# Altium schematic sheet DryCab.SchDoc
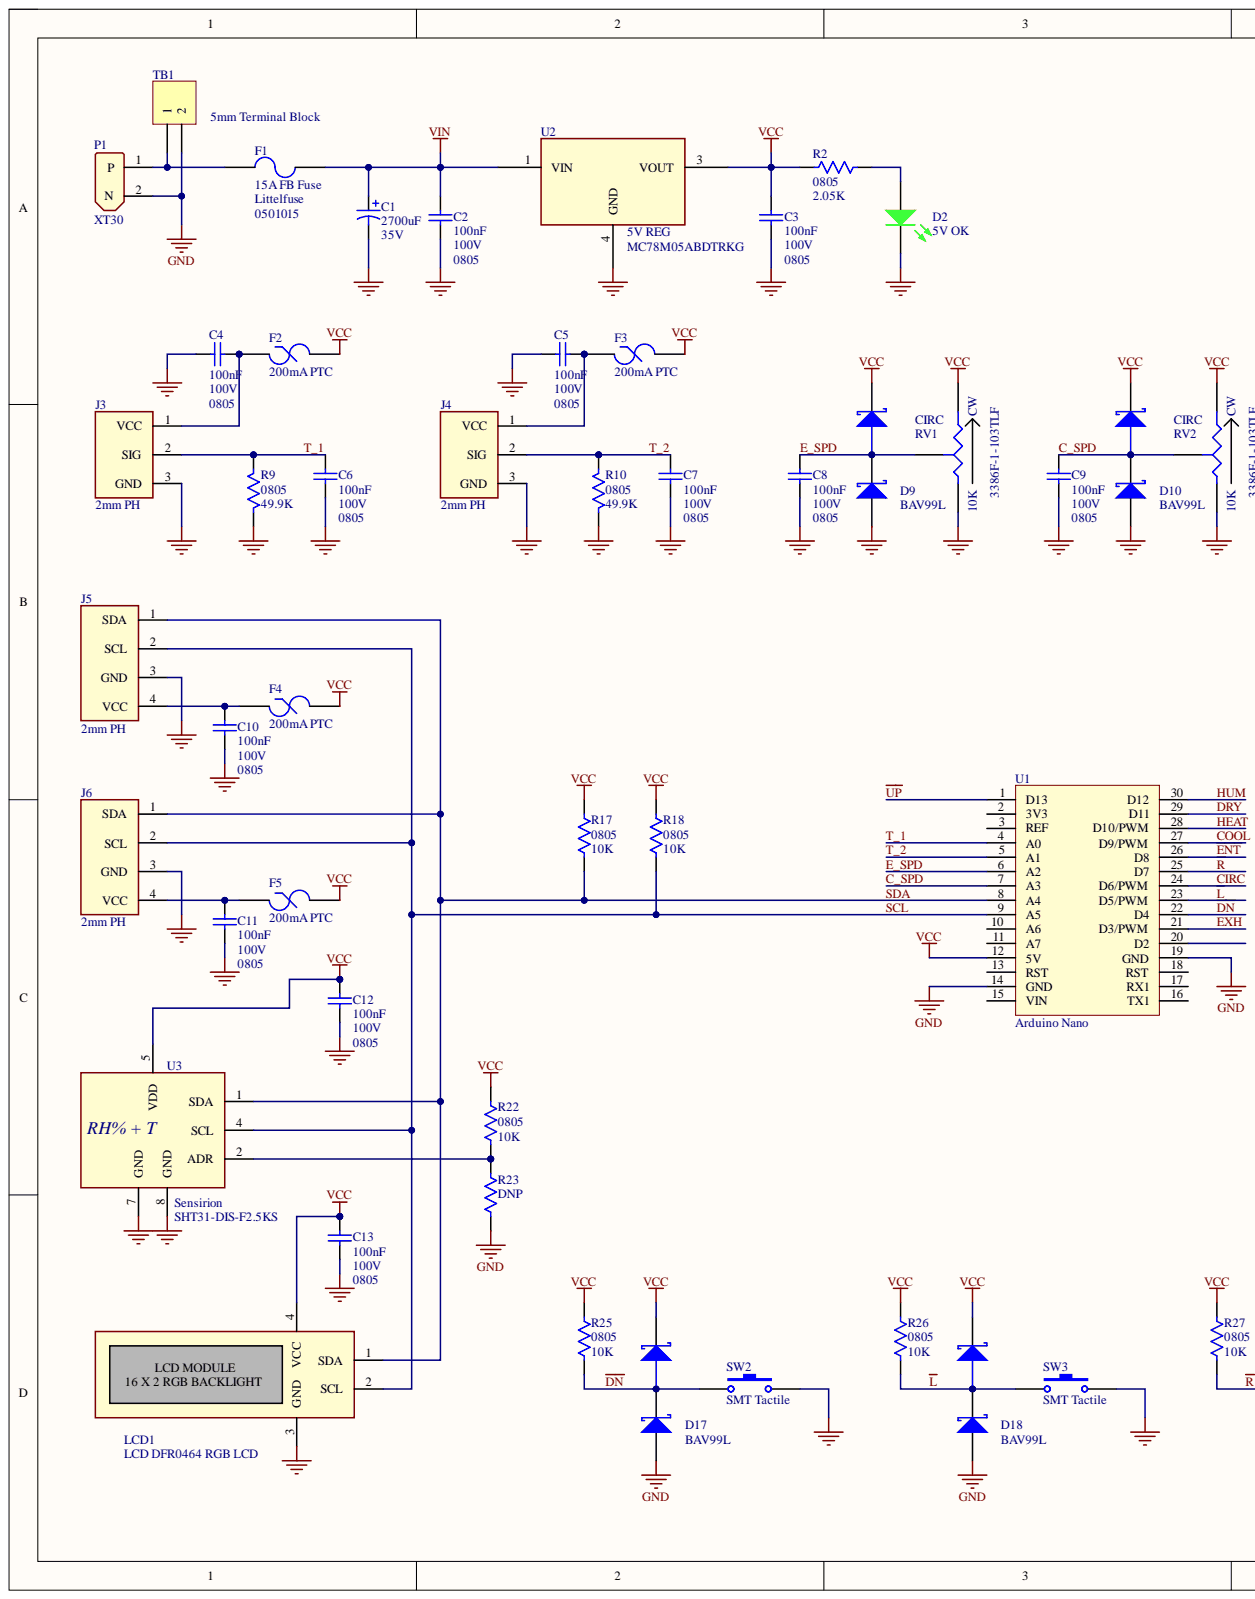
[diagram p0: DryCab.SchDoc, left]
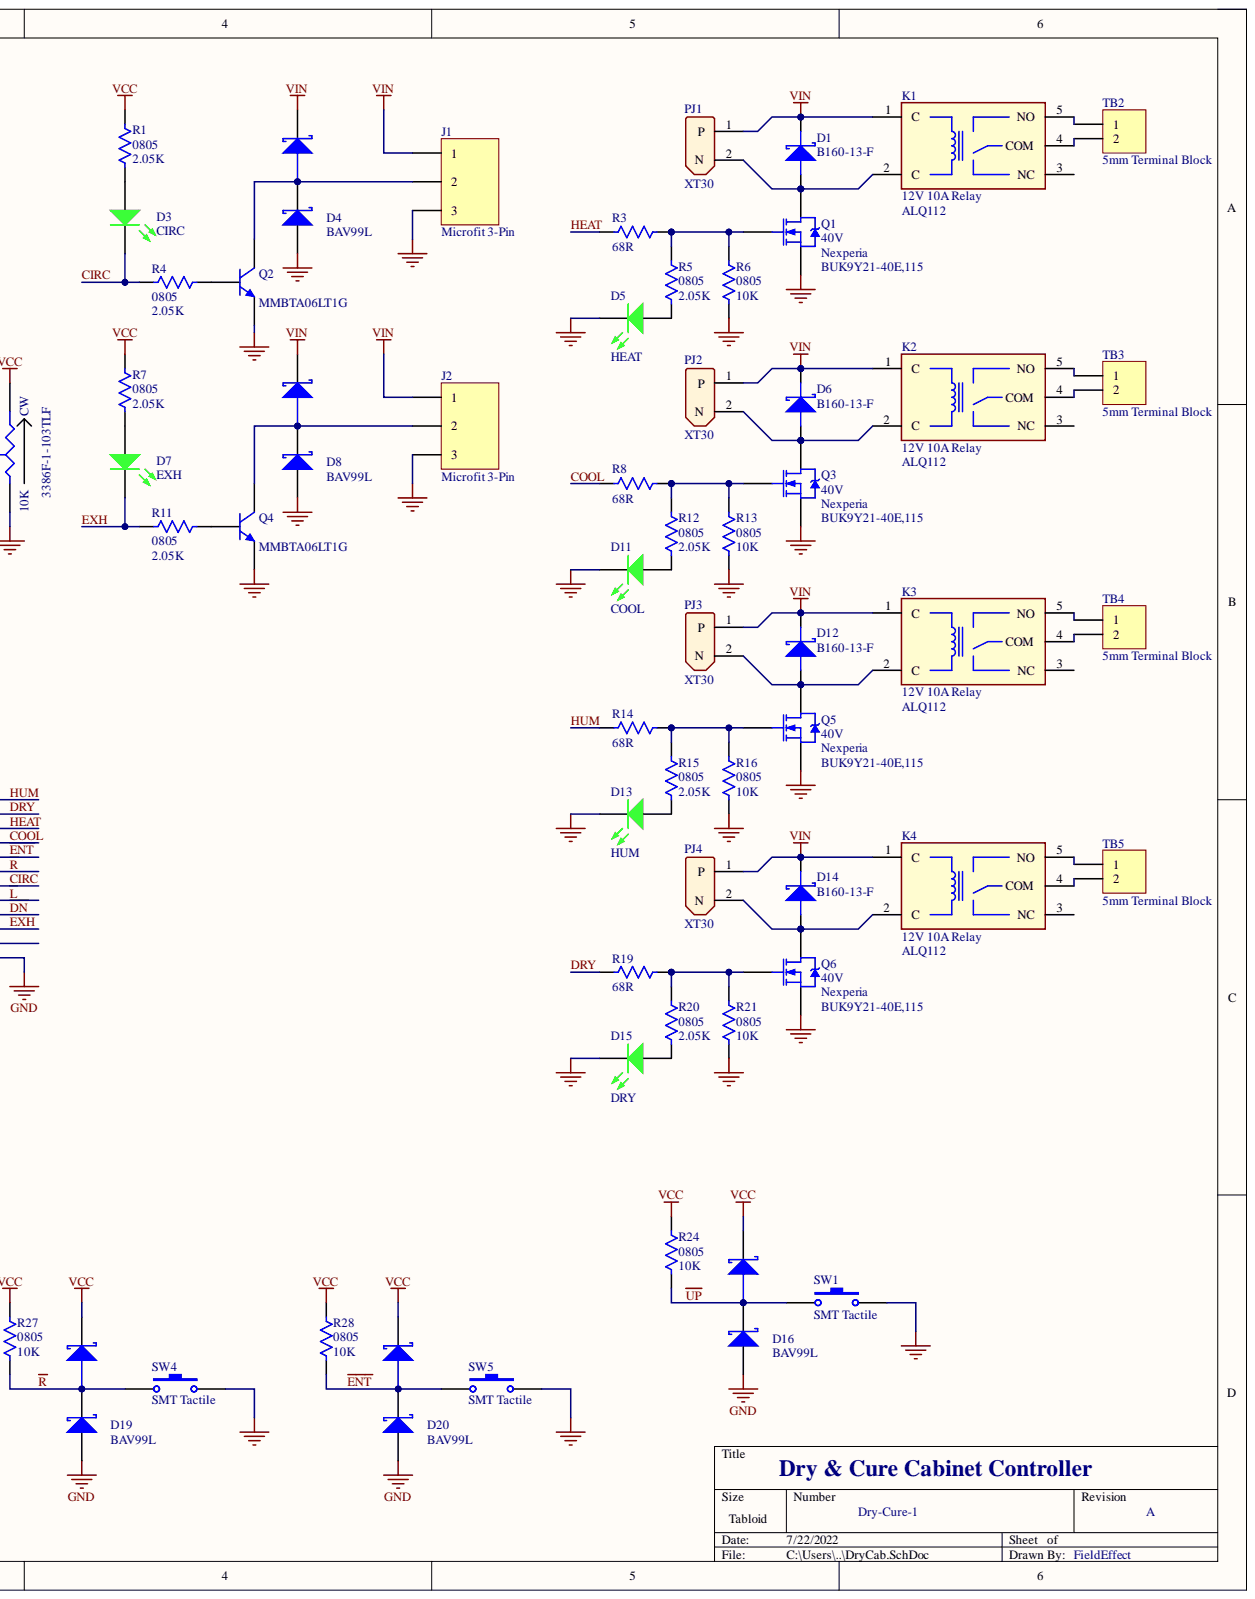
[diagram p1: DryCab.SchDoc, right]

## Parts
C1  value 2700uF  Voltage: 35V  [CAPAE209191X220M]  (hidden comment: C18mm_2700_35V)
C2  value 100nF  Voltage - Rated: 100V  [0805]  (hidden comment: C0805_100nF_100V_X7R_HR)
C3  value 100nF  Voltage - Rated: 100V  [0805]  (hidden comment: C0805_100nF_100V_X7R_HR)
C4  value 100nF  Voltage - Rated: 100V  [0805]  (hidden comment: C0805_100nF_100V_X7R_HR)
C5  value 100nF  Voltage - Rated: 100V  [0805]  (hidden comment: C0805_100nF_100V_X7R_HR)
C6  value 100nF  Voltage - Rated: 100V  [0805]  (hidden comment: C0805_100nF_100V_X7R_HR)
C7  value 100nF  Voltage - Rated: 100V  [0805]  (hidden comment: C0805_100nF_100V_X7R_HR)
C8  value 100nF  Voltage - Rated: 100V  [0805]  (hidden comment: C0805_100nF_100V_X7R_HR)
C9  value 100nF  Voltage - Rated: 100V  [0805]  (hidden comment: C0805_100nF_100V_X7R_HR)
C10  value 100nF  Voltage - Rated: 100V  [0805]  (hidden comment: C0805_100nF_100V_X7R_HR)
C11  value 100nF  Voltage - Rated: 100V  [0805]  (hidden comment: C0805_100nF_100V_X7R_HR)
C12  value 100nF  Voltage - Rated: 100V  [0805]  (hidden comment: C0805_100nF_100V_X7R_HR)
C13  value 100nF  Voltage - Rated: 100V  [0805]  (hidden comment: C0805_100nF_100V_X7R_HR)
D1  B160-13-F  [SMA]
D2  5V OK  [1206 LEDG]
D3  CIRC  [1206 LEDG]
D4  BAV99L  [SOT95P237X100-3N]
D5  HEAT  [1206 LEDG]
D6  B160-13-F  [SMA]
D7  EXH  [1206 LEDG]
D8  BAV99L  [SOT95P237X100-3N]
D9  BAV99L  [SOT95P237X100-3N]
D10  BAV99L  [SOT95P237X100-3N]
D11  COOL  [1206 LEDG]
D12  B160-13-F  [SMA]
D13  HUM  [1206 LEDG]
D14  B160-13-F  [SMA]
D15  DRY  [1206 LEDG]
D16  BAV99L  [SOT95P237X100-3N]
D17  BAV99L  [SOT95P237X100-3N]
D18  BAV99L  [SOT95P237X100-3N]
D19  BAV99L  [SOT95P237X100-3N]
D20  BAV99L  [SOT95P237X100-3N]
F1  15A FB Fuse  Manufacturer: Littelfuse  Manufacturer Part Number: 0501015  [1206 - PTC]
F2  200mA PTC  [1206 - PTC]
F3  200mA PTC  [1206 - PTC]
F4  200mA PTC  [1206 - PTC]
F5  200mA PTC  [1206 - PTC]
J1  Microfit 3-Pin  [MICROFIT 3-PIN FLAT VERT]
J2  Microfit 3-Pin  [MICROFIT 3-PIN FLAT VERT]
J3  2mm PH  [PH-3 THV cab]
J4  2mm PH  [PH-3 THV cab]
J5  2mm PH  [PH-4 THV CAB]
J6  2mm PH  [PH-4 THV CAB]
K1  12V 10A Relay  Coil Voltage (DC): 12V  Contact Current Rating: 10A  Manufacturer Part Number: ALQ112  [ALQ112 RELAY]
K2  12V 10A Relay  Coil Voltage (DC): 12V  Contact Current Rating: 10A  Manufacturer Part Number: ALQ112  [ALQ112 RELAY]
K3  12V 10A Relay  Coil Voltage (DC): 12V  Contact Current Rating: 10A  Manufacturer Part Number: ALQ112  [ALQ112 RELAY]
K4  12V 10A Relay  Coil Voltage (DC): 12V  Contact Current Rating: 10A  Manufacturer Part Number: ALQ112  [ALQ112 RELAY]
LCD1  LCD DFR0464 RGB LCD  [DFR I2C 16X2 DISP MOD]
P1  XT30  [XT30V]
PJ1  XT30  [XT30V]
PJ2  XT30  [XT30V]
PJ3  XT30  [XT30V]
PJ4  XT30  [XT30V]
Q1  40V  Manufacturer: Nexperia  Manufacturer Part Number: BUK9Y21-40E,115  [SOIC127P600X120-8N]
Q2  Manufacturer Part Number: MMBTA06LT1G  [SOT95P237X100-3N]  (hidden comment: NPN MMBTA06L SOT-23)
Q3  40V  Manufacturer: Nexperia  Manufacturer Part Number: BUK9Y21-40E,115  [SOIC127P600X120-8N]
Q4  Manufacturer Part Number: MMBTA06LT1G  [SOT95P237X100-3N]  (hidden comment: NPN MMBTA06L SOT-23)
Q5  40V  Manufacturer: Nexperia  Manufacturer Part Number: BUK9Y21-40E,115  [SOIC127P600X120-8N]
Q6  40V  Manufacturer: Nexperia  Manufacturer Part Number: BUK9Y21-40E,115  [SOIC127P600X120-8N]
R1  value 2.05K  [0805]  (hidden comment: R0805)
R2  value 2.05K  [0805]  (hidden comment: R0805)
R3  value 68R  [0805]
R4  value 2.05K  [0805]  (hidden comment: R0805)
R5  value 2.05K  [0805]  (hidden comment: R0805)
R6  value 10K  [0805]  (hidden comment: R0805)
R7  value 2.05K  [0805]  (hidden comment: R0805)
R8  value 68R  [0805]
R9  value 49.9K  [0805]  (hidden comment: R0805)
R10  value 49.9K  [0805]  (hidden comment: R0805)
R11  value 2.05K  [0805]  (hidden comment: R0805)
R12  value 2.05K  [0805]  (hidden comment: R0805)
R13  value 10K  [0805]  (hidden comment: R0805)
R14  value 68R  [0805]
R15  value 2.05K  [0805]  (hidden comment: R0805)
R16  value 10K  [0805]  (hidden comment: R0805)
R17  value 10K  [0805]  (hidden comment: R0805)
R18  value 10K  [0805]  (hidden comment: R0805)
R19  value 68R  [0805]
R20  value 2.05K  [0805]  (hidden comment: R0805)
R21  value 10K  [0805]  (hidden comment: R0805)
R22  value 10K  [0805]  (hidden comment: R0805)
R23  value DNP  [0805]  (hidden comment: R0805)
R24  value 10K  [0805]  (hidden comment: R0805)
R25  value 10K  [0805]  (hidden comment: R0805)
R26  value 10K  [0805]  (hidden comment: R0805)
R27  value 10K  [0805]  (hidden comment: R0805)
R28  value 10K  [0805]  (hidden comment: R0805)
RV1  CIRC  Manufacturer Part Number: 3386F-1-103TLF  Resistance: 10K  [POT 3386F-T]
RV2  CIRC  Manufacturer Part Number: 3386F-1-103TLF  Resistance: 10K  [POT 3386F-T]
SW1  SMT Tactile  [TL3305 PUSHBUTTON]
SW2  SMT Tactile  [TL3305 PUSHBUTTON]
SW3  SMT Tactile  [TL3305 PUSHBUTTON]
SW4  SMT Tactile  [TL3305 PUSHBUTTON]
SW5  SMT Tactile  [TL3305 PUSHBUTTON]
TB1  5mm Terminal Block  [2-Pin 5mm Term Block]
TB2  5mm Terminal Block  [2-Pin 5mm Term Block]
TB3  5mm Terminal Block  [2-Pin 5mm Term Block]
TB4  5mm Terminal Block  [2-Pin 5mm Term Block]
TB5  5mm Terminal Block  [2-Pin 5mm Term Block]
U1  Arduino Nano  [ARDUINONANO]
U2  5V REG  Manufacturer Part Number: MC78M05ABDTRKG  Min Output Voltage: 5V  [DPAK228P990X238-3N]
U3  Manufacturer: Sensirion  Manufacturer Part Number: SHT31-DIS-F2.5KS  [QFN50P250X250X100_HS-9N]  (hidden comment: Enviro. Sensor)

## Nets
GND: C1.2 C2.2 C3.2 C4.1 C5.1 C6.2 C7.2 C8.2 C9.2 C10.1 C11.1 C12.1 C13.1 D2.2 D4.1 D5.2 D8.1 D9.1 D10.1 D11.2 D13.2 D15.2 D16.1 D17.1 D18.1 D19.1 D20.1 J1.3 J2.3 J3.3 J4.3 J5.3(GND) J6.3(GND) LCD1.3(GND) P1.2 Q1.1 Q2.2 Q3.1 Q4.2 Q5.1 Q6.1 R6.2 R9.2 R10.2 R13.2 R16.2 R21.2 R23.2 RV1.1 RV2.1 SW1.2 SW2.2 SW3.2 SW4.2 SW5.2 TB1.2 U1.14(GND) U1.19(GND) U2.4 U3.7(GND) U3.8(GND)
VCC: C3.1 C12.2 C13.2 D9.2 D10.2 D16.2 D17.2 D18.2 D19.2 D20.2 F2.1 F3.1 F4.1 F5.1 LCD1.4(VCC) R1.2 R2.1 R7.2 R17.1 R18.1 R22.1 R24.1 R25.1 R26.1 R27.1 R28.1 RV1.3 RV2.3 U1.12(5V) U2.3 U3.5(VDD)
VIN: C1.1 C2.1 D1.K D4.2 D6.K D8.2 D12.K D14.K F1.2 J1.1 J2.1 K1.1(C) K2.1(C) K3.1(C) K4.1(C) PJ1.1 PJ2.1 PJ3.1 PJ4.1 U2.1
C_SPD: C9.1 D10.3 RV2.2 U1.7(A3)
CIRC: D3.2 R4.2 U1.24(D6/PWM)
COOL: R8.1 U1.27(D9/PWM)
~{DN}: D17.3 R25.2 SW2.1 U1.22(D4)
DRY: R19.1 U1.29(D11)
~{ENT}: D20.3 R28.2 SW5.1 U1.26(D8)
E_SPD: C8.1 D9.3 RV1.2 U1.6(A2)
EXH: D7.2 R11.2 U1.21(D3/PWM)
HEAT: R3.1 U1.28(D10/PWM)
HUM: R14.1 U1.30(D12)
~{L}: D18.3 R26.2 SW3.1 U1.23(D5/PWM)
~{R}: D19.3 R27.2 SW4.1 U1.25(D7)
SCL: J5.2(SCL) J6.2(SCL) LCD1.2(SCL) R18.2 U1.9(A5) U3.4(SCL)
SDA: J5.1(SDA) J6.1(SDA) LCD1.1(SDA) R17.2 U1.8(A4) U3.1(SDA)
T_1: C6.1 J3.2 R9.1 U1.4(A0)
T_2: C7.1 J4.2 R10.1 U1.5(A1)
~{UP}: D16.3 R24.2 SW1.1 U1.1(D13)
NetC4_2: C4.2 F2.2 J3.1
NetC5_2: C5.2 F3.2 J4.1
NetC10_2: C10.2 F4.2 J5.4(VCC)
NetC11_2: C11.2 F5.2 J6.4(VCC)
NetD1_A: D1.A K1.2(C) PJ1.2 Q1.3
NetD2_1: D2.1 R2.2
NetD3_1: D3.1 R1.1
NetD4_3: D4.3 J1.2 Q2.3
NetD5_1: D5.1 R5.1
NetD6_A: D6.A K2.2(C) PJ2.2 Q3.3
NetD7_1: D7.1 R7.1
NetD8_3: D8.3 J2.2 Q4.3
NetD11_1: D11.1 R12.1
NetD12_A: D12.A K3.2(C) PJ3.2 Q5.3
NetD13_1: D13.1 R15.1
NetD14_A: D14.A K4.2(C) PJ4.2 Q6.3
NetD15_1: D15.1 R20.1
NetF1_1: F1.1 P1.1 TB1.1
NetK1_4: K1.4(COM) TB2.2
NetK1_5: K1.5(NO) TB2.1
NetK2_4: K2.4(COM) TB3.2
NetK2_5: K2.5(NO) TB3.1
NetK3_4: K3.4(COM) TB4.2
NetK3_5: K3.5(NO) TB4.1
NetK4_4: K4.4(COM) TB5.2
NetK4_5: K4.5(NO) TB5.1
NetQ1_2: Q1.2 R3.2 R5.2 R6.1
NetQ2_1: Q2.1 R4.1
NetQ3_2: Q3.2 R8.2 R12.2 R13.1
NetQ4_1: Q4.1 R11.1
NetQ5_2: Q5.2 R14.2 R15.2 R16.1
NetQ6_2: Q6.2 R19.2 R20.2 R21.1
NetR22_2: R22.2 R23.1 U3.2(ADR)

Not connected: K1 3(NC); K2 3(NC); K3 3(NC); K4 3(NC); U1 2(3V3) 3(REF) 10(A6) 11(A7) 13(RST) 15(VIN) 16(TX1) 17(RX1) 18(RST) 20(D2)

## Library data of the parts
C1 = C18mm_2700uF_35V: 35V, 2700uF, Rubycon Electrolytic; Manufacturer Part Number: 35TRV2700M18X21.5; Component Kind: Standard; Library Name: S3.SchLib; Manufacturer: Rubycon; Supplier Part Number 1: 1189-3565-1-ND
C2-C13 = C0805_100nF_100V_X7R_HR: Capacitor, Ceramic, X7R, 100nF, 100V, 0805; Tolerance: ±10%; Component Kind: Standard; Library Name: S3.SchLib; Mounting Type: Surface Mount, MLCC; Package / Case: 0805; Temperature Coefficient: X7R
D1 D6 D12 D14 = B160-13-F: Schottky Diode, 80V, SMA; Manufacturer: Diodes Incorporated; Capacitance @ Vr, F: 110pF @ 4V, 1MHz; Current - Average Rectified (Io): 1A; Current - Reverse Leakage @ Vr: 500µA @ 60V; Speed: Fast Recovery =< 500ns, > 200mA (Io); Voltage - Forward (Vf) (Max) @ If: 700mV @ 1A; Category: Discrete Semiconductor Products; Component Kind: Standard; ComponentLink1Description: https://www.diodes.com/assets/Datasheets/ds13002.pdf; ComponentLink1URL: https://www.diodes.com/assets/Datasheets/ds13002.pdf; Diode Type: Schottky; Library Name: S3.SchLib; Manufacturer Part Number: B160-13-F; Mounting Type: Surface Mount; Operating Temperature - Junction: -65°C ~ 150°C; Package / Case: DO-214AC, SMA; Packaging: Cut Tape (CT); Part Status: Active; Pricing 1: 1=0.37, 10=0.304, 100=0.1615, 500=0.10622, 1000=0.07223 (USD); RoHS: RoHS Compliant; Stock 1: 175108; Supplier 1: Digi-Key; Supplier Device Package: SMA; Supplier Part Number 1: B160-FDICT-ND
D2 D3 D5 D7 D11 D13 D15 = LED_GREEN_1206: 1206 Green LED 2V; Manufacturer: Lite-On Inc.; Manufacturer Part Number: LTST-C150KGKT; Current - Test: 20mA; Lens Color: Colorless; Lens Style/Size: Rectangle with Flat Top, 2.06mm x 1.60mm; Lens Transparency: Clear; Millicandela Rating: 44.5mcd; Viewing Angle: 130°; Wavelength - Dominant: 571nm; Wavelength - Peak: 574nm; Category: Optoelectronics; Color: Green; Component Kind: Standard; ComponentLink1Description: http://optoelectronics.liteon.com/upload/download/DS22-2000-072/LTST-C150KGKT.pdf; ComponentLink1URL: http://optoelectronics.liteon.com/upload/download/DS22-2000-072/LTST-C150KGKT.pdf; Features: -; Height (Max): 1.10mm; Library Name: S3.SchLib; Mounting Type: Surface Mount; Package / Case: 1206 (3216 Metric); Packaging: Cut Tape (CT); Part Status: Active; Pricing 1: 1=0.38, 10=0.281, 25=0.2072, 100=0.148, 250=0.1006, 500=0.08878, 1000=0.06954 (USD); RoHS: RoHS Compliant; Size / Dimension: 3.20mm L x 1.60mm W; Stock 1: 194230; Supplier 1: Digi-Key; Supplier Device Package: 1206; Supplier Part Number 1: 160-1404-1-ND; Voltage - Forward (Vf) (Typ): 2V
D4 D8-D10 D16-D20 = BAV99L: SOT-23 Load Protect Diode Package; Component Kind: Standard; ComponentLink1Description: http://www.onsemi.com/pub/Collateral/BAV99WT1-D.PDF; ComponentLink1URL: http://www.onsemi.com/pub/Collateral/BAV99WT1-D.PDF; Library Name: S3.SchLib
F1 = Fuse, 15A, 1206: Fuse, 32V, 15A, 1206; Approvals: CE, cURus; Fuse Type: Board Mount (Cartridge Style Excluded); Response Time: Fast; Category: Circuit Protection; Component Kind: Standard; ComponentLink1Description: https://belfuse.com/resources/StewartConnector/C1S.pdf; ComponentLink1URL: https://belfuse.com/resources/StewartConnector/C1S.pdf; Library Name: S3.SchLib; Mounting Type: Surface Mount; Packaging: Cut Tape (CT); RoHS: RoHS Compliant; Supplier 1: Digi-Key
F2-F5 = PTC, 0.2A, 1206: Fuse, Resettable 200mA, 1206; Manufacturer: Bel Fuse Inc.; Manufacturer Part Number: 0ZCJ0020FF2E; Approvals: cURus, TUV; Type: Polymeric; Voltage - Max: 30V; Category: Circuit Protection; Component Kind: Standard; ComponentLink1Description: https://www.belfuse.com/resources/CircuitProtection/datasheets/0ZCJ%20Nov2016.pdf; ComponentLink1URL: https://www.belfuse.com/resources/CircuitProtection/datasheets/0ZCJ%20Nov2016.pdf; Height - Seated (Max): -; Lead Spacing: -; Library Name: S3.SchLib; Mounting Type: Surface Mount; Operating Temperature: -40°C ~ 85°C; Package / Case: 1206; Packaging: Cut Tape (CT); Part Status: Active; Pricing 1: 1=0.13, 5=0.13, 10=0.125, 50=0.1114, 100=0.1037, 250=0.09216, 500=0.0768, 1000=0.07296 (USD); Ratings: -; RoHS: RoHS Compliant; Size / Dimension: 0.128" L x 0.065" W (3.25mm x 1.65mm); Stock 1: 212891; Supplier 1: Digi-Key; Supplier Part Number 1: 507-1797-1-ND; Thickness (Max): 0.030" (0.75mm)
J1 J2 = Microfit 3-Pin S VERT: Header, Microfit Single-Row Vert; Manufacturer: Molex, LLC; Manufacturer Part Number: 0436500319; Contact Length - Post: 0.125" (3.18mm); Mated Stacking Heights: 17.56mm; Material Flammability Rating: UL94 V-0; Number of Positions: 3; Number of Positions Loaded: All; Pitch - Mating: 0.118" (3.00mm); Shrouding: Shrouded - 4 Wall; Style: Board to Cable/Wire; Applications: General Purpose, Medical, Military, Telecommunications; Category: Connectors, Interconnects; Component Kind: Standard; ComponentLink1Description: http://www.molex.com/pdm_docs/sd/436500319_sd.pdf; ComponentLink1URL: http://www.molex.com/pdm_docs/sd/436500319_sd.pdf; Connector Type: Header; Contact Finish - Mating: Gold; Contact Finish - Post: Tin; Contact Finish Thickness - Mating: 15µin (0.38µm); Contact Finish Thickness - Post: 100µin (2.54µm); Contact Length - Mating: -; Contact Material: Brass; Contact Shape: Square; Contact Type: Male Pin; Current Rating: -; Fastening Type: Locking Ramp; Features: Board Lock; Ingress Protection: -; Insulation Color: Black; Insulation Height: 0.390" (9.91mm); Insulation Material: Liquid Crystal Polymer (LCP), Glass Filled; Library Name: S3.SchLib; Mounting Type: Through Hole; Number of Rows: 1; Operating Temperature: -; Overall Contact Length: -; Packaging: Tray; Part Status: Active; Pricing 1: 1=1.18, 10=1.034, 100=0.8919, 500=0.72972, 1000=0.64864, 5000=0.56756, 10000=0.53918 (USD); RoHS: RoHS Compliant; Row Spacing - Mating: -; Stock 1: 2841; Supplier 1: Digi-Key; Supplier Part Number 1: WM7498-ND; Termination: Kinked Pin, Solder; Voltage Rating: -
J3 J4 = JST PH3 SENSOR: Component Kind: Standard; Library Name: S3.SchLib
J5 J6 = JST PH4 I2C: Component Kind: Standard; Library Name: S3.SchLib
K1-K4 = REL LQ112: PANASONIC - ALQ112 - POWER RELAY, SPDT, 12VDC, 10A, THD; Coil Current: 33.3mA; Coil Power: 400mW; Coil Resistance: 360R; Coil Type: Non-Latching; Max Voltage Rating (AC): 250V; Operate Time: 20ms; Relay Type: General Purpose; Category: Power Relays; Component Kind: Standard; ComponentLink1Description: https://datasheet.ciiva.com/24388/307ds-61b20-en-lq-24388909.pdf?src-supplier=Onlinecomponents.com; ComponentLink1URL: https://datasheet.ciiva.com/24388/307ds-61b20-en-lq-24388909.pdf?src-supplier=Onlinecomponents.com; Contact Material: Silver; Depth: 10mm; Height: 16mm; Lead Free: Lead Free; Length: 20mm; Library Name: S3.SchLib; Manufacturer: Panasonic; Max Operating Temperature: 85°C; Min Operating Temperature: -40°C; Number of Pins: 5; Package Quantity: 100; Packaging: Carton; Power Consumption: 400mW; Power Rating: 400mW; Pricing 1: 1=2.71000, 10=2.54500, 25=2.26240, 50=2.14920, 100=2.03620, 250=1.80992, 500=1.69680, 1000=1.58368, 5000=1.52712 (USD); REACH SVHC: Unknown; Release Time: 20ms; RoHS: Yes; RoHS Compliant: Yes; Series: ALQ; Stock 1: 2316; Supplier 1: Digi-Key; Supplier Part Number 1: 255-3562-ND; Termination: PC Pin; Throw Configuration: SPDT; Voltage Rating (AC): 250V; Weight: 7g; Width: 10mm
Q1 Q3 Q5 Q6 = NMOS BUK9Y21 SO8-T: BUK9Y21-40E - N-channel 40 V, 21 mΩ logic level MOSFET in LFPAK56; Category: MOSFETs; Component Kind: Standard; ComponentLink1Description: https://assets.nexperia.com/documents/data-sheet/BUK9Y21-40E.pdf?src-supplier=Digi-Key; ComponentLink1URL: https://assets.nexperia.com/documents/data-sheet/BUK9Y21-40E.pdf?src-supplier=Digi-Key; Library Name: S3.SchLib; Pricing 1: 1=0.65000, 10=0.57300, 100=0.43920, 500=0.34716 (USD); RoHS: Yes; RoHS Compliant: Yes; Stock 1: 1259; Supplier 1: Digi-Key; Supplier Part Number 1: 1727-1123-1-ND
Q2 Q4 = NPN MMBTA06L SOT-23: NPN 80V SOT-23; Manufacturer: ON Semiconductor; Current - Collector Cutoff (Max): 100nA; DC Current Gain (hFE) (Min) @ Ic, Vce: 100 @ 100mA, 1V; Frequency - Transition: 100MHz; Vce Saturation (Max) @ Ib, Ic: 250mV @ 10mA, 100mA; Base Part Number: MMBTA06; Category: Discrete Semiconductor Products; Component Kind: Standard; ComponentLink1Description: http://www.onsemi.com/pub/Collateral/MMBTA05LT1-D.PDF; ComponentLink1URL: http://www.onsemi.com/pub/Collateral/MMBTA05LT1-D.PDF; Library Name: S3.SchLib; Mounting Type: Surface Mount; Operating Temperature: -55°C ~ 150°C (TJ); Package / Case: TO-236-3, SC-59, SOT-23-3; Packaging: Cut Tape (CT); Part Status: Active; Pricing 1: 1=0.22, 10=0.205, 100=0.1118, 500=0.06878, 1000=0.04689 (USD); RoHS: RoHS Compliant; Stock 1: 269492; Supplier 1: Digi-Key; Supplier Device Package: SOT-23-3 (TO-236); Supplier Part Number 1: MMBTA06LT1GOSCT-ND; Transistor Type: NPN
R1 R2 R4 R5 R7 R11 R12 R15 R20 = R0805_2K: Resistor, 2K, 1/8W, 0805; Manufacturer: Panasonic Electronic Components; Manufacturer Part Number: ERJ-6ENF2051V; Power (Watts): 0.125W, 1/8W; Temperature Coefficient: ±100ppm/°C; Tolerance: ±1%; Category: Resistors; Component Kind: Standard; ComponentLink1Description: https://industrial.panasonic.com/cdbs/www-data/pdf/RDA0000/AOA0000C304.pdf; ComponentLink1URL: https://industrial.panasonic.com/cdbs/www-data/pdf/RDA0000/AOA0000C304.pdf; Composition: Thick Film; Failure Rate: -; Features: Automotive AEC-Q200; Height - Seated (Max): 0.028" (0.70mm); Library Name: S3.SchLib; Operating Temperature: -55°C ~ 155°C; Package / Case: 0805 (2012 Metric); Packaging: Cut Tape (CT); Part Status: Active; Pricing 1: 1=0.1, 10=0.079, 100=0.0305, 1000=0.0134, 2500=0.01157 (USD); RoHS: RoHS Compliant; Size / Dimension: 0.079" L x 0.049" W (2.00mm x 1.25mm); Stock 1: 147165; Supplier 1: Digi-Key; Supplier Device Package: 0805; Supplier Part Number 1: P2.05KCCT-ND
R3 R8 R14 R19 = R0805_--R: Resistor, -R, 1/8W, 0805; Component Kind: Standard; Library Name: S3.SchLib
R6 R13 R16-R18 R21 R22 R24-R28 = R0805_10K: Resistor, 10K, 1/8W, 0805; Manufacturer: Panasonic Electronic Components; Manufacturer Part Number: ERJ-P06F1002V; Power (Watts): 0.5W, 1/2W; Temperature Coefficient: ±100ppm/°C; Tolerance: ±1%; Category: Resistors; Component Kind: Standard; ComponentLink1Description: https://industrial.panasonic.com/cdbs/www-data/pdf/RDO0000/AOA0000C331.pdf; ComponentLink1URL: https://industrial.panasonic.com/cdbs/www-data/pdf/RDO0000/AOA0000C331.pdf; Composition: Thick Film; Failure Rate: -; Features: Automotive AEC-Q200, Pulse Withstanding; Height - Seated (Max): 0.028" (0.70mm); Library Name: S3.SchLib; Operating Temperature: -55°C ~ 155°C; Package / Case: 0805 (2012 Metric); Packaging: Cut Tape (CT); Part Status: Active; Pricing 1: 1=0.19, 10=0.159, 100=0.0622, 1000=0.02604, 2500=0.02386 (USD); RoHS: RoHS Compliant; Size / Dimension: 0.079" L x 0.049" W (2.00mm x 1.25mm); Stock 1: 98018; Supplier 1: Digi-Key; Supplier Device Package: 0805; Supplier Part Number 1: P16059CT-ND
R9 R10 = R0805_49.9K: Resistor, 49.9K, 1/8W, 0805; Manufacturer: Panasonic Electronic Components; Manufacturer Part Number: ERJ-6ENF4992V; Power (Watts): 0.125W, 1/8W; Temperature Coefficient: ±100ppm/°C; Tolerance: ±1%; Category: Resistors; Component Kind: Standard; ComponentLink1Description: https://industrial.panasonic.com/cdbs/www-data/pdf/RDA0000/AOA0000C304.pdf; ComponentLink1URL: https://industrial.panasonic.com/cdbs/www-data/pdf/RDA0000/AOA0000C304.pdf; Composition: Thick Film; Failure Rate: -; Features: Automotive AEC-Q200; Height - Seated (Max): 0.028" (0.70mm); Library Name: S3.SchLib; Operating Temperature: -55°C ~ 155°C; Package / Case: 0805 (2012 Metric); Packaging: Cut Tape (CT); Part Status: Active; Pricing 1: 1=0.1, 10=0.079, 100=0.0305, 1000=0.0134, 2500=0.01157 (USD); RoHS: RoHS Compliant; Size / Dimension: 0.079" L x 0.049" W (2.00mm x 1.25mm); Stock 1: 155523; Supplier 1: Digi-Key; Supplier Device Package: 0805; Supplier Part Number 1: P49.9KCCT-ND
R23 = R0805_DNP: Resistor, Footprint, 0805; Component Kind: Standard; Library Name: S3.SchLib
RV1 RV2 = R_3386F-T_10K_POT: Res Cermet Trimmer 10K Ohm 10% 1/2W 1(Elec)/1(Mech)Turn 8.38mm (9.53 X 9.53 X 10.41mm) Pin Thru-Hole Tube; Manufacturer: Bourns; Max Power Dissipation: 500mW; Number of Turns: 1; Temperature Coefficient: 100ppm/°C; Voltage Rating: 300V; Category: Variable Resistors and Potentiometers; Color: Blue; Component Kind: Standard; ComponentLink1Description: http://www.bourns.com/docs/Product-Datasheets/3214.pdf; ComponentLink1URL: http://www.bourns.com/docs/Product-Datasheets/3214.pdf; ComponentLink2Description: https://datasheet.ciiva.com/11831/0900766b8069ccf9-11831221.pdf?src-supplier=RSComponents; ComponentLink2URL: https://datasheet.ciiva.com/11831/0900766b8069ccf9-11831221.pdf?src-supplier=RSComponents; ComponentLink3Description: https://datasheet.ciiva.com/29122/447357-da-01-en-trimmer-tht3386f-1-103tlf-10k-0-5w-29122237.pdf?src-supplier=Conrad; ComponentLink3URL: https://datasheet.ciiva.com/29122/447357-da-01-en-trimmer-tht3386f-1-103tlf-10k-0-5w-29122237.pdf?src-supplier=Conrad; ComponentLink4Description: https://datasheet.ciiva.com/8701/3386-8701593.pdf?src-supplier=Avnet; ComponentLink4URL: https://datasheet.ciiva.com/8701/3386-8701593.pdf?src-supplier=Avnet; ComponentLink5Description: https://datasheet.ciiva.com/15156/getdatasheetpartid-239796-15156892.pdf?src-supplier=Verical; ComponentLink5URL: https://datasheet.ciiva.com/15156/getdatasheetpartid-239796-15156892.pdf?src-supplier=Verical; ComponentLink6Description: https://datasheet.ciiva.com/2156/3386-2156919.pdf?src-supplier=Digi-Key; ComponentLink6URL: https://datasheet.ciiva.com/2156/3386-2156919.pdf?src-supplier=Digi-Key; ComponentLink7Description: https://datasheet.ciiva.com/7953/1815119-7953071.pdf?src-supplier=Element14; ComponentLink7URL: https://datasheet.ciiva.com/7953/1815119-7953071.pdf?src-supplier=Element14; Depth: 9.53mm; Height: 0.19inch; Lead Free: Lead Free; Length: 9.53mm; Library Name: S3.SchLib; Max Operating Temperature: 125°C; Military Standard: Not; Min Operating Temperature: -55°C; Mount: Through Hole; Package Quantity: 100; Packaging: Rail/Tube; Power Rating: 500mW; Pricing 1: 1=2.48000, 10=2.17500, 25=1.95000, 50=1.87500, 100=1.80000, 250=1.72500, 500=1.42500, 1000=1.35000, 5000=1.12500 (USD); Radiation Hardening: No; REACH SVHC: No SVHC; Resistance Tolerance: 10%; Resistor Type: Cermet; RoHS: Yes; RoHS Compliant: Yes; Series: Trimpot® 3386 - Sealed; Stock 1: 4838; Supplier 1: Digi-Key; Supplier Part Number 1: 3386F-103TLF-ND; Taper: Linear; Technology: CERMET; Termination: Pin; Tolerance: 10%; Weight: 0.03oz; Width: 9.53mm
SW1-SW5 = PB 5mm SMT Tactile 3305: Component Kind: Standard; Library Name: S3.SchLib
TB1-TB5 = 2-Pin 5mm Terminal Block: 5mm 2-Position Terminal Block; Manufacturer: Phoenix Contact; Manufacturer Part Number: 1715022; Current: 15A; Mating Orientation: Horizontal with Board; Number of Levels: 1; Pitch: 0.197" (5.00mm); Positions Per Level: 2; Screw Thread: M3; Torque - Screw: 0.5-0.6 Nm (4.4-5.3 Lb-In); Voltage: 300V; Wire Gauge: 14-30 AWG; Wire Termination: Screw - Rising Cage Clamp; Category: Connectors, Interconnects; Clamp Material - Plating: -; Color: Green; Component Kind: Standard; ComponentLink1Description: http://media.digikey.com/pdf/Data%20Sheets/Phoenix%20Contact%20PDFs/1715022.pdf; ComponentLink1URL: http://media.digikey.com/pdf/Data%20Sheets/Phoenix%20Contact%20PDFs/1715022.pdf; Contact Material - Plating: -; Features: Interlocking (Side); Housing Material: Polyamide (PA), Nylon; Library Name: S3.SchLib; Mounting Type: Through Hole; Operating Temperature: -; Packaging: Bulk; Pricing 1: 1=1.2, 10=1.15, 50=1.0996, 100=1.0696, 250=0.9996, 500=0.91964, 1000=0.833, 2500=0.7938, 5000=0.7448 (USD); RoHS: RoHS Compliant; Screw Material - Plating: -; Stock 1: 1183; Supplier 1: Digi-Key; Supplier Part Number 1: 277-1258-ND
U2 = MC7805-D: MC78M00 Series 500 mA 5 V Fixed Output Surface Mount LDO Regulator - TO-252; Manufacturer: ON Semiconductor; Accuracy: 2%; Dropout Voltage: 2V; Max Input Voltage: 35V; Max Output Current: 500mA; Max Output Voltage: 5.1V; Min Input Voltage: 7V; Number of Outputs: 1; Output Type: Fixed,Adjustable; Polarity: Positive; Power Supply Rejection Ratio (PSRR): 80dB; Quiescent Current: 800uA; Case/Package: DPAK; Category: Voltage Regulators - Linear; Component Kind: Standard; ComponentLink1Description: https://datasheet.ciiva.com/30477/0900766b811a657c-30477334.pdf?src-supplier=RSComponents; ComponentLink1URL: https://datasheet.ciiva.com/30477/0900766b811a657c-30477334.pdf?src-supplier=RSComponents; ComponentLink2Description: https://datasheet.ciiva.com/2060/mc78m00-d-2060719.pdf?src-supplier=Digi-Key; ComponentLink2URL: https://datasheet.ciiva.com/2060/mc78m00-d-2060719.pdf?src-supplier=Digi-Key; ComponentLink3Description: https://datasheet.ciiva.com/2060/mc78m00-d-2060720.pdf?src-supplier=Digi-Key; ComponentLink3URL: https://datasheet.ciiva.com/2060/mc78m00-d-2060720.pdf?src-supplier=Digi-Key; ComponentLink4Description: https://datasheet.ciiva.com/2060/mc78m00-d-2060721.pdf?src-supplier=Digi-Key; ComponentLink4URL: https://datasheet.ciiva.com/2060/mc78m00-d-2060721.pdf?src-supplier=Digi-Key; ComponentLink5Description: https://datasheet.ciiva.com/26179/mc78m00-d-26179835.pdf?src-supplier=Avnet+Europe; ComponentLink5URL: https://datasheet.ciiva.com/26179/mc78m00-d-26179835.pdf?src-supplier=Avnet+Europe; ComponentLink6Description: https://datasheet.ciiva.com/16455/mc78m00-d-16455405.pdf?src-supplier=Future+Electronics; ComponentLink6URL: https://datasheet.ciiva.com/16455/mc78m00-d-16455405.pdf?src-supplier=Future+Electronics; ComponentLink7Description: https://datasheet.ciiva.com/1643/1746757-1643712.pdf?src-supplier=Newark; ComponentLink7URL: https://datasheet.ciiva.com/1643/1746757-1643712.pdf?src-supplier=Newark; Contact Plating: Tin,Matte; Halogen Free: Halogen Free; Height: 2.38mm; Lead Free: Lead Free; Length: 6.73mm; Library Name: S3.SchLib; Max Operating Temperature: 125°C; Min Operating Temperature: -40°C; Mount: Surface Mount; Nominal Output Voltage: 5V; Number of Pins: 3; Number of Regulators: 1; Output Current: 500mA; Output Voltage: 5V; Output Voltage Accuracy: 2%; Package Quantity: 1; Packaging: Tape and Reel; Pricing 1: 1=0.60000, 10=0.53100, 25=0.49880, 100=0.40710, 250=0.37812, 500=0.32182, 1000=0.26565 (USD); Radiation Hardening: No; REACH SVHC: No SVHC; RoHS: Yes; RoHS Compliant: Yes; Series: -; Stock 1: 328; Supplier 1: Digi-Key; Supplier Part Number 1: MC78M05ABDTRKGOSCT-ND; Width: 6.22mm
U3 = SHT31: Humidity & Temperature Sensor ±2% RH ±0.3°C I2C DFN8 with Filter; Response Time: 8s; Accuracy: 2%; Category: Sensors; Component Kind: Standard; ComponentLink1Description: http://www.farnell.com/datasheets/2646599.pdf?src-supplier=Farnell; ComponentLink1URL: http://www.farnell.com/datasheets/2646599.pdf?src-supplier=Farnell; ComponentLink2Description: http://www.farnell.com/datasheets/2756487.pdf?src-supplier=Farnell; ComponentLink2URL: http://www.farnell.com/datasheets/2756487.pdf?src-supplier=Farnell; ComponentLink3Description: https://www.sensirion.com/file/datasheet_filter-membrane?src-supplier=Digi-Key; ComponentLink3URL: https://www.sensirion.com/file/datasheet_filter-membrane?src-supplier=Digi-Key; Library Name: S3.SchLib; Max Operating Temperature: 125°C; Min Operating Temperature: -40°C; Mount: Surface Mount; Output Type: I2C; Pricing 1: 1=8.79000, 5=7.75600, 10=6.85000, 25=5.55760, 50=4.91160, 100=4.78220, 500=4.00676, 1000=3.74825 (USD); RoHS: Yes; Sensor Type: Temperature; Stock 1: 12956; Supplier 1: Digi-Key; Supplier Part Number 1: 1649-1066-1-ND
LCD1 = LCD DFR0464 RGB LCD: Component Kind: Standard; Library Name: S3.SchLib
P1 PJ1-PJ4 = XT30: Component Kind: Standard; Library Name: S3.SchLib
U1 = Arduino Nano: Component Kind: Standard; Library Name: S3.SchLib
